# Altium schematic sheet p1_bt_sorry.SchDoc (continued)
## Library data of the parts (continued)
SW1 = Pushbutton Switch, Blue Illuminated: SWITCH TACTILE SPST-NO 50MA 12V; Manufacturer: TE Connectivity Alcoswitch; Operating Force: 100gf; ClassName: SMT; Component Kind: Standard; ComponentLink1Description: http://www.farnell.com/datasheets/2074198.pdf?src-supplier=Element14; ComponentLink1URL: http://www.farnell.com/datasheets/2074198.pdf?src-supplier=Element14; Contact Current Rating: 50mA; Contact Material: Brass; Contact Plating: Silver; Illumination Type: Illuminated; Library Name: Misc.SchLib; Manufacturer Part Number: FSMIJM61AB04; Mount: Surface Mount; Pricing 1: 1 = 3.90000, 10 = 3.74600, 25 = 3.44600, 50 = 2.99660, 100 = 2.84680 (USD); REACH SVHC: No SVHC; RoHS: No; Stock 1: 1218; Supplier 1: Digikey; Supplier Part Number 1: 450-2207-1-ND
TP1-TP4 = TP SMT 0.5MM: 0.5mm SMT Test Point; ClassName: Testpoints; Component Kind: Standard; Library Name: Misc.SchLib
U1 = EXC-14CS350H: CMC 100MA 2LN 35 OHM SMD ESD; Manufacturer: Panasonic; ClassName: SMT,Sensitive Exception; Component Kind: Standard; Library Name: ICs.SchLib; Manufacturer Part Number: EXC-14CS350H; Pricing 1: 1 = 0.22000, 10 = 0.20200, 100 = 0.13490, 500 = 0.11242, 1000 = 0.09444, 2500 = 0.08095 (USD); RoHS: No; Stock 1: 12872; Supplier 1: Digi-Key; Supplier Part Number 1: P19421CT-ND
U2 = MDBT42Q: MDBT42Q NRF52832 BASED BLE MODUL; Manufacturer: Seeed Studio; Manufacturer Part Number: 317030213; ClassName: SMT,Sensitive Exception; Component Kind: Standard; ComponentLink1Description: http://statics3.seeedstudio.com/assets/file/bazaar/product/MDBT42Q-Version_B.pdf?src-supplier=Digi-Key; ComponentLink1URL: http://statics3.seeedstudio.com/assets/file/bazaar/product/MDBT42Q-Version_B.pdf?src-supplier=Digi-Key; Library Name: ICs.SchLib; Pricing 1: 1 = 10.10000 (USD); RoHS: No; Stock 1: 333; Supplier 1: Digi-Key; Supplier Part Number 1: 1597-1434-ND
U3 = MCP73831T-2ATI/OT: IC CONTROLLR LI-ION 4.2V SOT23-5; Manufacturer: Microchip; Max Charge Current: 500mA; Max Output Voltage: 4.232V; Max Supply Voltage: 6V; Min Output Voltage: 4.168V; Min Supply Voltage: 3.75V; Number of Cells: 1; Case/Package: SOT-23; ClassName: SMT; Component Kind: Standard; ComponentLink1Description: https://datasheet.ciiva.com/26344/651522-da-01-en-contr-mcp73831t-2ati-ot-sot-23-5-mcp-26344457.pdf?src-supplier=Conrad; ComponentLink1URL: https://datasheet.ciiva.com/26344/651522-da-01-en-contr-mcp73831t-2ati-ot-sot-23-5-mcp-26344457.pdf?src-supplier=Conrad; ComponentLink2Description: https://datasheet.ciiva.com/1931/21984e-1931956.pdf?src-supplier=Digi-Key; ComponentLink2URL: https://datasheet.ciiva.com/1931/21984e-1931956.pdf?src-supplier=Digi-Key; ComponentLink3Description: https://datasheet.ciiva.com/1931/21984e-1931957.pdf?src-supplier=Digi-Key; ComponentLink3URL: https://datasheet.ciiva.com/1931/21984e-1931957.pdf?src-supplier=Digi-Key; ComponentLink4Description: https://datasheet.ciiva.com/1931/21984e-1931958.pdf?src-supplier=Digi-Key; ComponentLink4URL: https://datasheet.ciiva.com/1931/21984e-1931958.pdf?src-supplier=Digi-Key; ComponentLink5Description: https://datasheet.ciiva.com/17299/21984e-17299304.pdf?src-supplier=Avnet+Europe; ComponentLink5URL: https://datasheet.ciiva.com/17299/21984e-17299304.pdf?src-supplier=Avnet+Europe; ComponentLink6Description: https://datasheet.ciiva.com/1137/630369-1137804.pdf?src-supplier=Element14; ComponentLink6URL: https://datasheet.ciiva.com/1137/630369-1137804.pdf?src-supplier=Element14; ComponentLink7Description: https://datasheet.ciiva.com/8039/630369-8039388.pdf?src-supplier=Element14; ComponentLink7URL: https://datasheet.ciiva.com/8039/630369-8039388.pdf?src-supplier=Element14; ComponentLink8Description: https://datasheet.ciiva.com/16217/filehandler-aspxddocname-en025112-16217052.pdf?src-supplier=Future+Electronics; ComponentLink8URL: https://datasheet.ciiva.com/16217/filehandler-aspxddocname-en025112-16217052.pdf?src-supplier=Future+Electronics; ComponentLink9Description: https://datasheet.ciiva.com/3213/21984e-3213405.pdf?src-supplier=Avnet; ComponentLink9URL: https://datasheet.ciiva.com/3213/21984e-3213405.pdf?src-supplier=Avnet; Height: 1.3mm; Lead Free: Lead Free; Length: 3.1mm; Library Name: ICs.SchLib; Manufacturer Part Number: MCP73831T-2ATI/OT; Max Operating Temperature: 85°C; Max Output Current: 500mA; Min Operating Temperature: -40°C; Mount: Surface Mount; Number of Pins: 5; Output Current: 500mA; Output Voltage: 4.2V; Package Quantity: 3000; Packaging: Tape and Reel; Pricing 1: 1 = 0.58000, 25 = 0.48400, 100 = 0.43260 (USD); REACH SVHC: No SVHC; RoHS: Yes; Stock 1: 22234; Supplier 1: Digi-Key; Supplier Part Number 1: MCP73831T-2ATI/OTCT-ND; Width: 1.8mm
U4 = CP2104-F03-GMR: IC SGL USB-TO-UART BRIDGE 24QFN; Manufacturer: Silicon Labs; Data Rate: 2Mbps; Interface: UART; Max Supply Voltage: 3.6V; Min Supply Voltage: 3V; Number of Channels: 1; Number of I/Os: 4; Operating Supply Current: 17mA; Operating Supply Voltage: 3.3V; Case/Package: QFN EP; ClassName: SMT,Sensitive Exception; Component Kind: Standard; ComponentLink1Description: https://datasheet.ciiva.com/14939/cp2104-14939979.pdf?src-supplier=Digi-Key; ComponentLink1URL: https://datasheet.ciiva.com/14939/cp2104-14939979.pdf?src-supplier=Digi-Key; ComponentLink2Description: https://datasheet.ciiva.com/16639/cp2104-16639756.pdf?src-supplier=Avnet+Europe; ComponentLink2URL: https://datasheet.ciiva.com/16639/cp2104-16639756.pdf?src-supplier=Avnet+Europe; ComponentLink3Description: https://datasheet.ciiva.com/15150/getdatasheetpartid-711722-15150533.pdf?src-supplier=Verical; ComponentLink3URL: https://datasheet.ciiva.com/15150/getdatasheetpartid-711722-15150533.pdf?src-supplier=Verical; Contact Plating: Tin; Library Name: ICs.SchLib; Manufacturer Part Number: CP2104-F03-GMR; Max Operating Temperature: 85°C; Min Operating Temperature: -40°C; Mount: Surface Mount; Number of Pins: 24; Package Quantity: 1500; Packaging: Tape and Reel; Pricing 1: 1 = 1.65000, 25 = 1.58640, 100 = 1.58360 (USD); Radiation Hardening: No; RoHS: Yes; Stock 1: 20484; Supplier 1: Digi-Key; Supplier Part Number 1: 336-4146-1-ND; Weight: 0.001764oz
U5 = TPS7A9001DSKR: ULTRA LOW NOISE 0.5A LDO WITH P; Manufacturer: Texas Instruments; ClassName: SMT; Component Kind: Standard; Library Name: ICs.SchLib; Manufacturer Part Number: TPS7A9001DSKR; Max Operating Temperature: 125°C; Min Operating Temperature: -40°C; Pricing 1: 1=1.6, 10=1.441, 100=1.1584, 500=0.95172, 1000=0.78857 (USD); Stock 1: 105; Supplier 1: Digi-Key; Supplier Part Number 1: 296-48316-1-ND
